# Altium schematic sheet Mojo.SchDoc(Mojo)
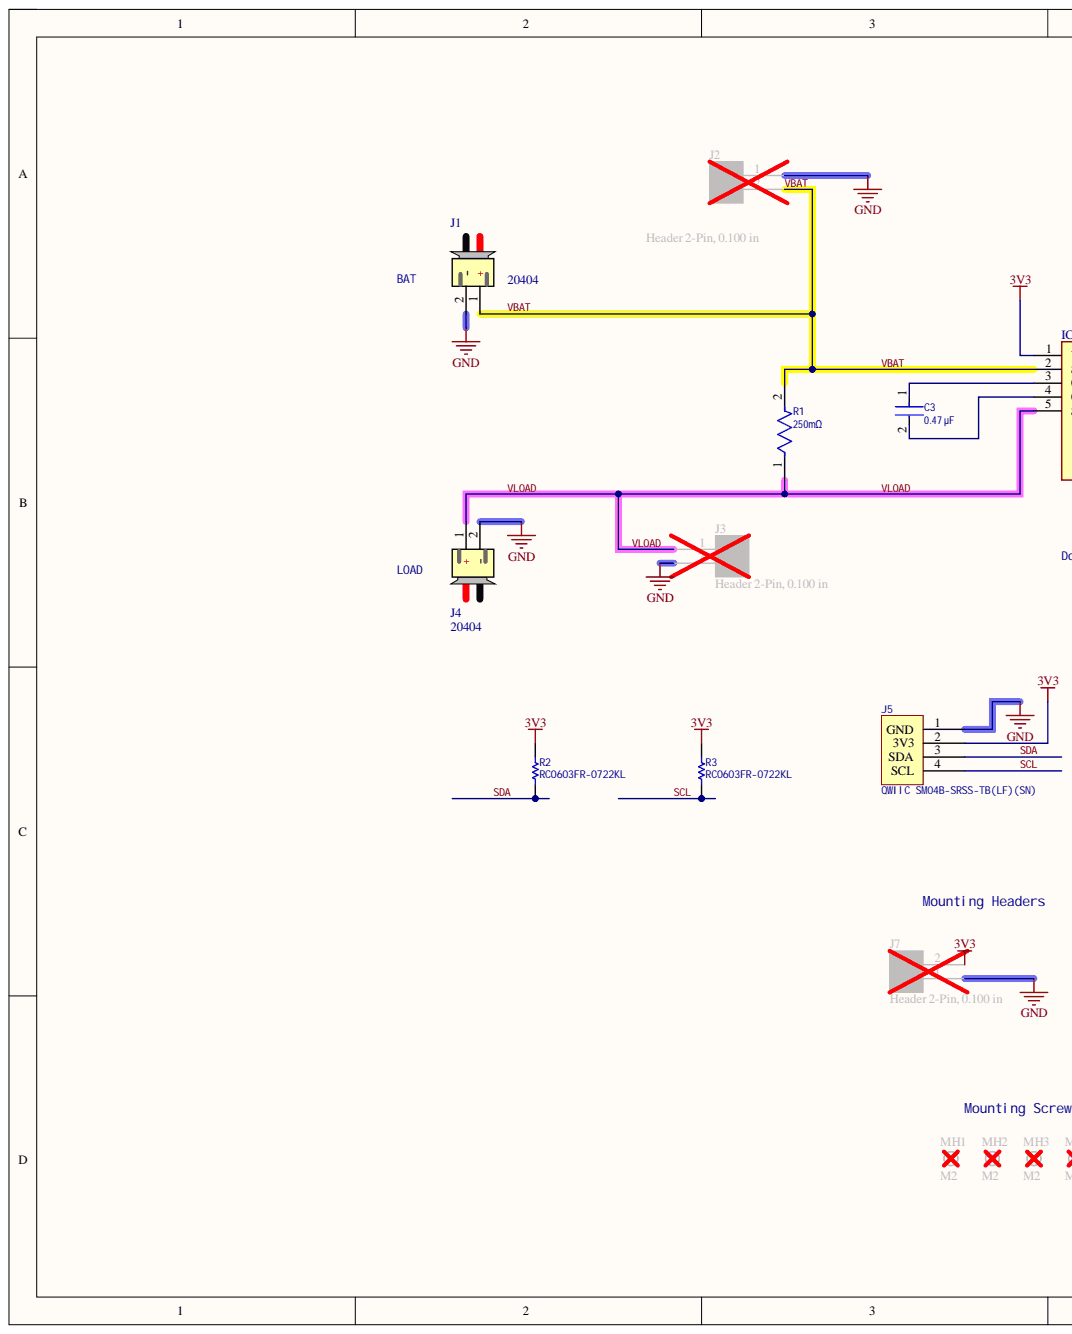
[diagram p0: Mojo.SchDoc, left]
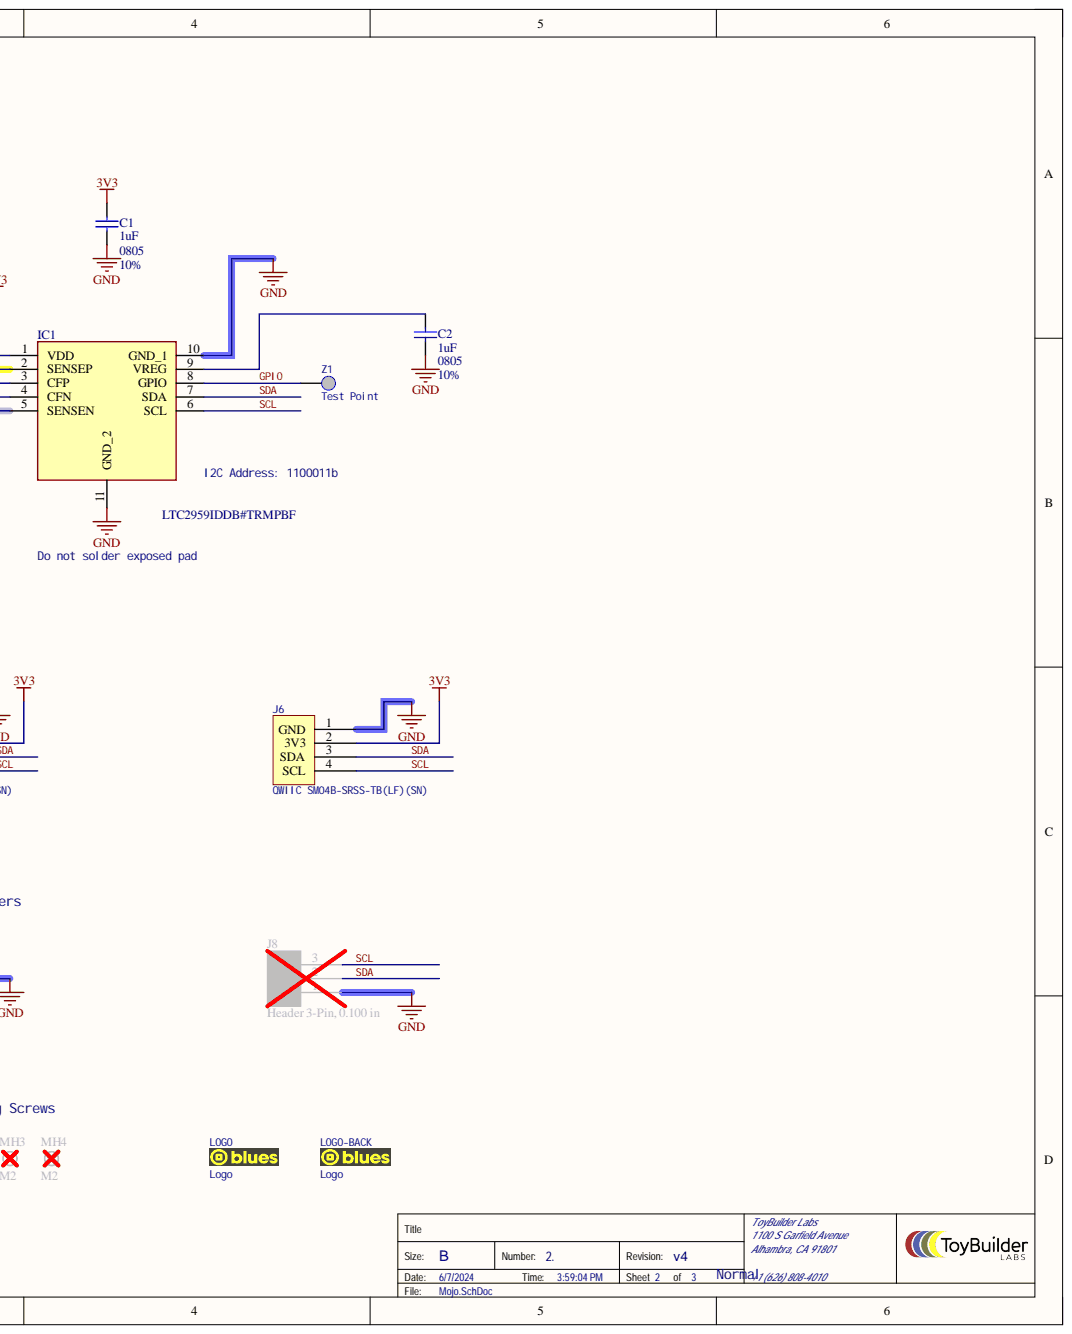
[diagram p1: Mojo.SchDoc, right]

## Parts
C1  Capacitance: 1uF  Case/Package: 0805  Tolerance: 10%  [CAPC2013X70X45NL10T25]  (hidden comment: GRM216R61E105KA12D)
C2  Capacitance: 1uF  Case/Package: 0805  Tolerance: 10%  [CAPC2013X70X45NL10T25]  (hidden comment: GRM216R61E105KA12D)
C3  value 0.47 μF  Capacitance: 0.47 μF  [FP-C0805C-DN-MFG]  (hidden comment: C0805C474K5RACTU)
IC1  LTC2959IDDB#TRMPBF  [SON50P200X300X80-11N-D]
J1  20404  [BAT-AF-S2B-PH-SM4]
J2  Header 2-Pin, 0.100 in  [HEADER_AA_002]
J3  Header 2-Pin, 0.100 in  [HEADER_AA_002]
J4  20404  [BAT-AF-S2B-PH-SM4]
J5  QWIIC SM04B-SRSS-TB(LF)(SN)  Manufacturer Part Number: SM04B-SRSS-TB(LF)(SN)  [QWIIC_SM04B-SRSS]
J6  QWIIC SM04B-SRSS-TB(LF)(SN)  Manufacturer Part Number: SM04B-SRSS-TB(LF)(SN)  [QWIIC_SM04B-SRSS]
J7  Header 2-Pin, 0.100 in  [HEADER_AA_002]
J8  Header 3-Pin, 0.100 in  [HEADER_AA_003]
MH1  M2  [HOLE_2MM]  (no BOM)
MH2  M2  [HOLE_2MM]  (no BOM)
MH3  M2  [HOLE_2MM]  (no BOM)
MH4  M2  [HOLE_2MM]  (no BOM)
R1  Resistance: 250mΩ  [FP-WSL2010-0_508-MFG]  (hidden comment: WSL2010R2500FEA)
R2  RC0603FR-0722KL  [RESC1608X55X25LL10T15]
R3  RC0603FR-0722KL  [RESC1608X55X25LL10T15]
Z1  Test Point  [testp_40pad]  (no BOM)

## Nets
3V3: C1.2 IC1.1(VDD) J5.2(3V3) J6.2(3V3) J7.2 R2.2 R3.2
GND: C1.1 C2.1 IC1.10(GND_1) IC1.11(GND_2) J1.2 J2.1 J3.2 J4.2 J5.1(GND) J6.1(GND) J7.1 J8.1
GPIO: IC1.8(GPIO) Z1.1
SCL: IC1.6(SCL) J5.4(SCL) J6.4(SCL) J8.3 R3.1
SDA: IC1.7(SDA) J5.3(SDA) J6.3(SDA) J8.2 R2.1
VBAT: IC1.2(SENSEP) J1.1 J2.2 R1.2
VLOAD: IC1.5(SENSEN) J3.1 J4.1 R1.1
NetC2_2: C2.2 IC1.9(VREG)
NetC3_1: C3.1 IC1.3(CFP)
NetC3_2: C3.2 IC1.4(CFN)

## Library data of the parts
C1 C2 = CMP-2007-00957-1: Manufacturer: Murata; Dielectric: X5R; Voltage: 25V; Component Kind: Standard; Library Name: Altium Content Vault; Manufacturer Part Number: GRM216R61E105KA12D; Part Number: GRM216R61E105KA12D; Supplier 1: Digi-Key; Supplier Part Number 1: 490-1700-1-ND
C3 = CMP-2007-03659-2: CAP CER 0.47UF 50V 10% X7R 0805; Manufacturer: KEMET; Material: Ceramic; Temperature Coefficient: 30ppm/°C; Tolerance: 0.1; Automotive: No; Category: Cap; Component Kind: Standard; ComponentLink2Description: Datasheet; ComponentLink2URL: https://content.kemet.com/datasheets/KEM_C1003_C0G_SMD.pdf; Device Class L1: Passive Components; Device Class L2: Capacitors; Device Class L3: Ceramic Capacitors; Digikey Description: CAP CER 0.47UF 50V 10% X7R 0805; Height: 0.88mm; Ipc Land Pattern Name: CAPC200125X78; Lead Free: Yes; Library Name: Altium Content Vault; Manufacturer Part Number: C0805C474K5RACTU; Mouser Description: 0805 C 470nF Ceramic Multilayer Capacitor, 50 VDC, +125degC, X7R Dielec, +/-10%; Octopart: https://octopart.com/c0805c474k5ractu-kemet-144543; Package: C0805C-DN; RoHS: TRUE; Temperature: -55°C to +125°C; Temperature Characteristic: X7R; Temperature Range High: +125°C; Temperature Range Low: -55°C; Voltage Rating: 50 V
IC1 = LTC2959IDDB#TRMPBF: Ultra-low-power Battery Gas Gaug; Manufacturer: Analog Devices; Category: Battery Management; Component Kind: Standard; ComponentLink1Description: Datasheet; ComponentLink1URL: https://www.analog.com/media/en/technical-documentation/data-sheets/ltc2959.pdf; Datasheet Link: https://www.analog.com/LTC2959/datasheet; Height: 0.8mm; Manufacturer Part Number: LTC2959IDDB#TRMPBF; Manufacturer_Name: Analog Devices; Manufacturer_Part_Number: LTC2959IDDB#TRMPBF; Mouser Part Number: 584-LTC2959IDDBTRMPB; Mouser Price/Stock: https://www.mouser.co.uk/ProductDetail/Analog-Devices/LTC2959IDDBTRMPBF?qs=pUKx8fyJudDXTmMT1kM1kQ%3D%3D; Pricing 1: 1=9.13, 10=8.252, 25=7.868, 100=6.8316, 250=6.5246 (USD); RoHS: No; Stock 1: 2988; Supplier 1: Digikey; Supplier Part Number 1: 505-LTC2959IDDB#TRMPBFCT-ND
J1 J4 = BAT-PH-ADAFRUIT-SMT-RA: Battery Connector PH-2POS wired for Adafruit style packs.  SMD RA 4UCON 20404 (similar to JST S2B-PH-SM4-TB(LF)(SN)); Cross 2: JST S2B-PH-SM4-TB(LF)(SN) (tight fit); Component Kind: Standard; ComponentLink1Description: http://www.farnell.com/datasheets/1699381.pdf; ComponentLink1URL: http://www.farnell.com/datasheets/1699381.pdf; Cross 1: Adafruit 1769; Library Name: TBL Battery Holders.SchLib; Manufacturer: 4UCON; Manufacturer Part Number: 20404; Mounting Type: SMD
J2 J3 J7 = HEADER_AA_2: Header 2-Pin, 0.100 inch (0.230 inch head); Manufacturer: Sullins; Manufacturer Part Number: PEC02SAAN; Number of Positions: 2; Component Kind: Standard; Function 1: Function 1; Function 2: Function 2; Mount: Through Hole; Number of Rows: 1; Library Name: TBLI-Headers.IntLib
J5 J6 = QWIIC_SM04B-SRSS: CONN HEADER SH 4POS SIDE 1MM TIN QWIIC pinout; Manufacturer: JST; Connector Type: Male Pin; Contact Resistance: 40mR; Max Current Rating: 1A; Max Voltage Rating (AC): 50V; Number of Contacts: 4; Pitch: 0.039inch; Category: Connectors; Color: Natural; Component Kind: Standard; ComponentLink1Description: https://datasheet.ciiva.com/26894/getdatasheetpartid-640736-26894676.pdf?src-supplier=Verical; ComponentLink1URL: https://datasheet.ciiva.com/26894/getdatasheetpartid-640736-26894676.pdf?src-supplier=Verical; ComponentLink2Description: https://datasheet.ciiva.com/26894/getdatasheetpartid-499363-26894945.pdf?src-supplier=Verical; ComponentLink2URL: https://datasheet.ciiva.com/26894/getdatasheetpartid-499363-26894945.pdf?src-supplier=Verical; ComponentLink3Description: https://datasheet.ciiva.com/16487/jstsm04b-srss-tb-lf-sn-16487222.pdf?src-supplier=Future+Electronics; ComponentLink3URL: https://datasheet.ciiva.com/16487/jstsm04b-srss-tb-lf-sn-16487222.pdf?src-supplier=Future+Electronics; Contact Material: Copper Alloy; Contact Plating: Tin,Copper; Depth: 4.95mm; Housing Color: Beige; Housing Material: Polyamide; Lead Free: Lead Free; Length: 6mm; Library Name: TBL Connectors.SchLib; Max Operating Temperature: 85°C; Max Voltage Rating (DC): 50V; Min Operating Temperature: -25°C; Mount: Surface Mount; Number of Positions: 4; Number of Rows: 1; Orientation: Right Angle; Packaging: Tape and Reel; Pricing 1: 1=0.57000, 10=0.49300, 100=0.40630, 500=0.35328, 1000=0.29146 (USD); RoHS: Yes; Supplier 1: Digi-Key; Supplier Part Number 1: 455-1804-1-ND; Termination: Solder
J8 = HEADER_AA_3: Header 3-Pin, 0.100 inch (0.230 inch head); Manufacturer: Sullins; Manufacturer Part Number: PEC03SAAN; Number of Positions: 3; Component Kind: Standard; Function 1: Function 1; Function 2: Function 2; Mount: Through Hole; Number of Rows: 1; Library Name: TBLI-Headers.IntLib
MH1-MH4 = Bare Hole M2: Bare Hole, M2; Library Name: TBL Mechanical.SchLib
R1 = RES_2020_0R250: 0R250 Metal Strp 2010 1% 1/2W 75Ppm/ C WSL2010R2500FEA; Manufacturer: Vishay Dale; Max Power Dissipation: 500mW; Temperature Coefficient: 75ppm/°C; Voltage Rating: 150mV; Case Code (Imperial): 2010; Case Code (Metric): 5025; Case/Package: 2010; Component Kind: Standard; Composition: Metal Element; Contact Plating: Tin; Depth: 2.54mm; Features: Moisture Resistant; Height: 635µm; Lead Free: Lead Free; Length: 5.08mm; Library Name: TBL Passives.SchLib; Manufacturer Part Number: WSL2010R2500FEA; Max Operating Temperature: 170°C; Military Standard: Not; Min Operating Temperature: -65°C; Mount: Surface Mount; Number of Pins: 2; Number of Terminations: 2; Packaging: Cut Tape; Power Rating: 500mW; Radiation Hardening: No; REACH SVHC: No SVHC; RoHS: Compliant; Schedule B: 8533210045, 8533210045; Termination: SMD/SMT; Tolerance: 1%; Voltage Rating (AC): 150mV; Voltage Rating (DC): 150mV; Weight: 38.895546mg; Width: 2.54mm
R2 R3 = CMP-1012-00659-3: Chip Resistor, 22 KOhm, +/- 1%, 0.1 W, -55 to 155 degC, 0603 (1608 Metric), RoHS, Tape and Reel; Current Rating: 290 mA; Resistance: 2.9 Ohm; Shielding: Unshielded; Tolerance: 10%; Case/Package: Radial; Component Kind: Standard; ComponentLink1Description: Manufacturer URL; ComponentLink1URL: http://www.yageo.com/; ComponentLink2Description: Datasheet; ComponentLink2URL: http://www.yageo.com/documents/recent/PYu-RC_Group_51_RoHS_L_04.pdf; DatasheetVersion: Rev. 4, 02/2016; Diameter: 8.5 mm; Height: 11 mm; Lead Pitch: 5 mm; Library Name: Altium Content Vault; Max Operating Temperature: 105degC; Min Operating Temperature: -55degC; Mount: Through Hole; Package Quantity: 100; PackageDescription: 2-Pin Surface Mount Device, Body 1.6 x 0.8 mm; PackageReference: 0603; Packaging: Bulk; Pins: 2; Radiation Hardening: No; REACH SVHC: No SVHC; RoHSCompliant: True
Z1 = test_pt: Description: Test point; Library Name: TBL Common.SchLib
